# Altium schematic sheet M100Z-M3&4_CPU_DE.SchDoc
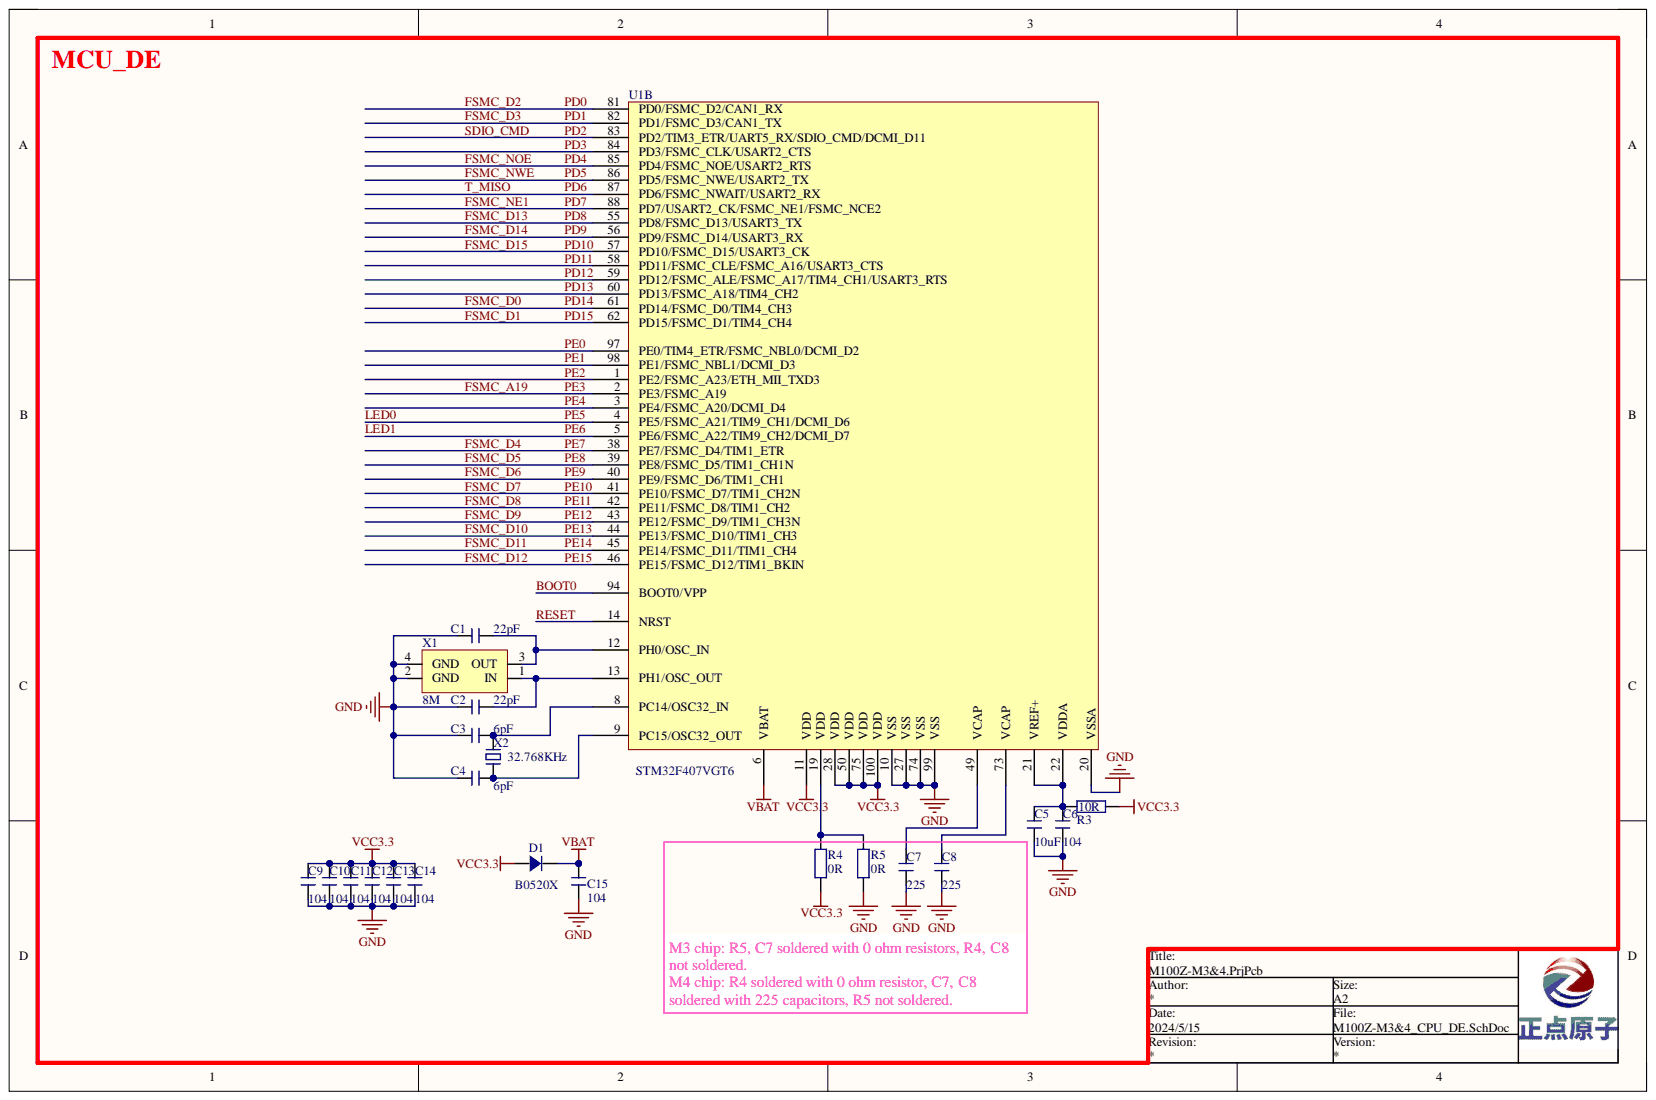
[diagram p0: M100Z-M3&4_CPU_DE.SchDoc]

## Parts
C1  22pF  [C 0402]
C2  22pF  [C 0402]
C3  6pF  [C 0402]
C4  6pF  [C 0402]
C5  10uF  [C 0402]
C6  104  [C 0402]
C7  225  [C 0402]
C8  225  [C 0402]
C9  104  [C 0402]
C10  104  [C 0402]
C11  104  [C 0402]
C12  104  [C 0402]
C13  104  [C 0402]
C14  104  [C 0402]
C15  104  [C 0402]
D1  B0520X  [SOD-523]
R3  10R  [R 0402]
R4  0R  [R 0402]
R5  0R  [R 0402]
U1  STM32F407VGT6  [LQFP100 14x14_L]  (part B)
X1  8M  [XTAL-3225]
X2  32.768KHz  [XTAL-3215]

## Nets
GND: C1.1 C2.1 C3.1 C4.1 C5.2 C6.2 C7.1 C8.1 C9.2 C10.2 C11.2 C12.2 C13.2 C14.2 C15.2 R5.1 U1.10 U1.20 U1.27 U1.74 U1.99 X1.2(GND) X1.4(GND)
VBAT: C15.1 D1.2 U1.6
VCC3.3: C9.1 C10.1 C11.1 C12.1 C13.1 C14.1 D1.1 R3.2 R4.1 U1.11 U1.28 U1.50 U1.75 U1.100
BOOT0: U1.94
FSMC_A19: U1.2
FSMC_D0: U1.61
FSMC_D1: U1.62
FSMC_D2: U1.81
FSMC_D3: U1.82
FSMC_D4: U1.38
FSMC_D5: U1.39
FSMC_D6: U1.40
FSMC_D7: U1.41
FSMC_D8: U1.42
FSMC_D9: U1.43
FSMC_D10: U1.44
FSMC_D11: U1.45
FSMC_D12: U1.46
FSMC_D13: U1.55
FSMC_D14: U1.56
FSMC_D15: U1.57
FSMC_NE1: U1.88
FSMC_NOE: U1.85
FSMC_NWE: U1.86
LED0: U1.4
LED1: U1.5
PD2: U1.83
PD3: U1.84
PD6: U1.87
PD11: U1.58
PD12: U1.59
PD13: U1.60
PE0: U1.97
PE1: U1.98
PE2: U1.1
PE4: U1.3
RESET: U1.14
NetC1_2: C1.2 U1.12 X1.3(OUT)
NetC2_2: C2.2 U1.13 X1.1(IN)
NetC3_2: C3.2 U1.8 X2.2
NetC4_2: C4.2 U1.9 X2.1
NetC5_1: C5.1 C6.1 R3.1 U1.21 U1.22
NetC7_2: C7.2 U1.49
NetC8_2: C8.2 U1.73
NetR4_2: R4.2 R5.2 U1.19

## Library data of the parts
C1-C15 = C: 无极性电容; BOM名称: 无极性贴片电容; 版权归属: 正点原子; Component Kind: Standard; Library Name: 电容.SchLib; 学习资源: www.openedv.com
D1 = D-US1M: 开关二级管; Component Kind: Standard; Library Name: 二极管.SchLib
R3-R5 = R: 电阻; BOM名称: 贴片电阻; 版权归属: 正点原子; Component Kind: Standard; Library Name: 电阻.SchLib; Parameter: 电阻; 学习资源: www.openedv.com
U1 = STM32F407VE: Component Kind: Standard; Library Name: 刘军临时封装库_SCH库.SchLib
X1 = XTAL-4P: 4脚无源晶振; BOM名称: 4脚晶振; Parameter: 晶振; 版权归属: 正点原子; Component Kind: Standard; Library Name: 晶振.SchLib; 学习资源: www.openedv.com
X2 = XTAL-2P: 2脚无源晶振; BOM名称: 2脚晶振; Parameter: 晶振; 版权归属: 正点原子; Component Kind: Standard; Library Name: 晶振.SchLib; 学习资源: www.openedv.com
